# Altium schematic sheet NOTECARD.SchDoc
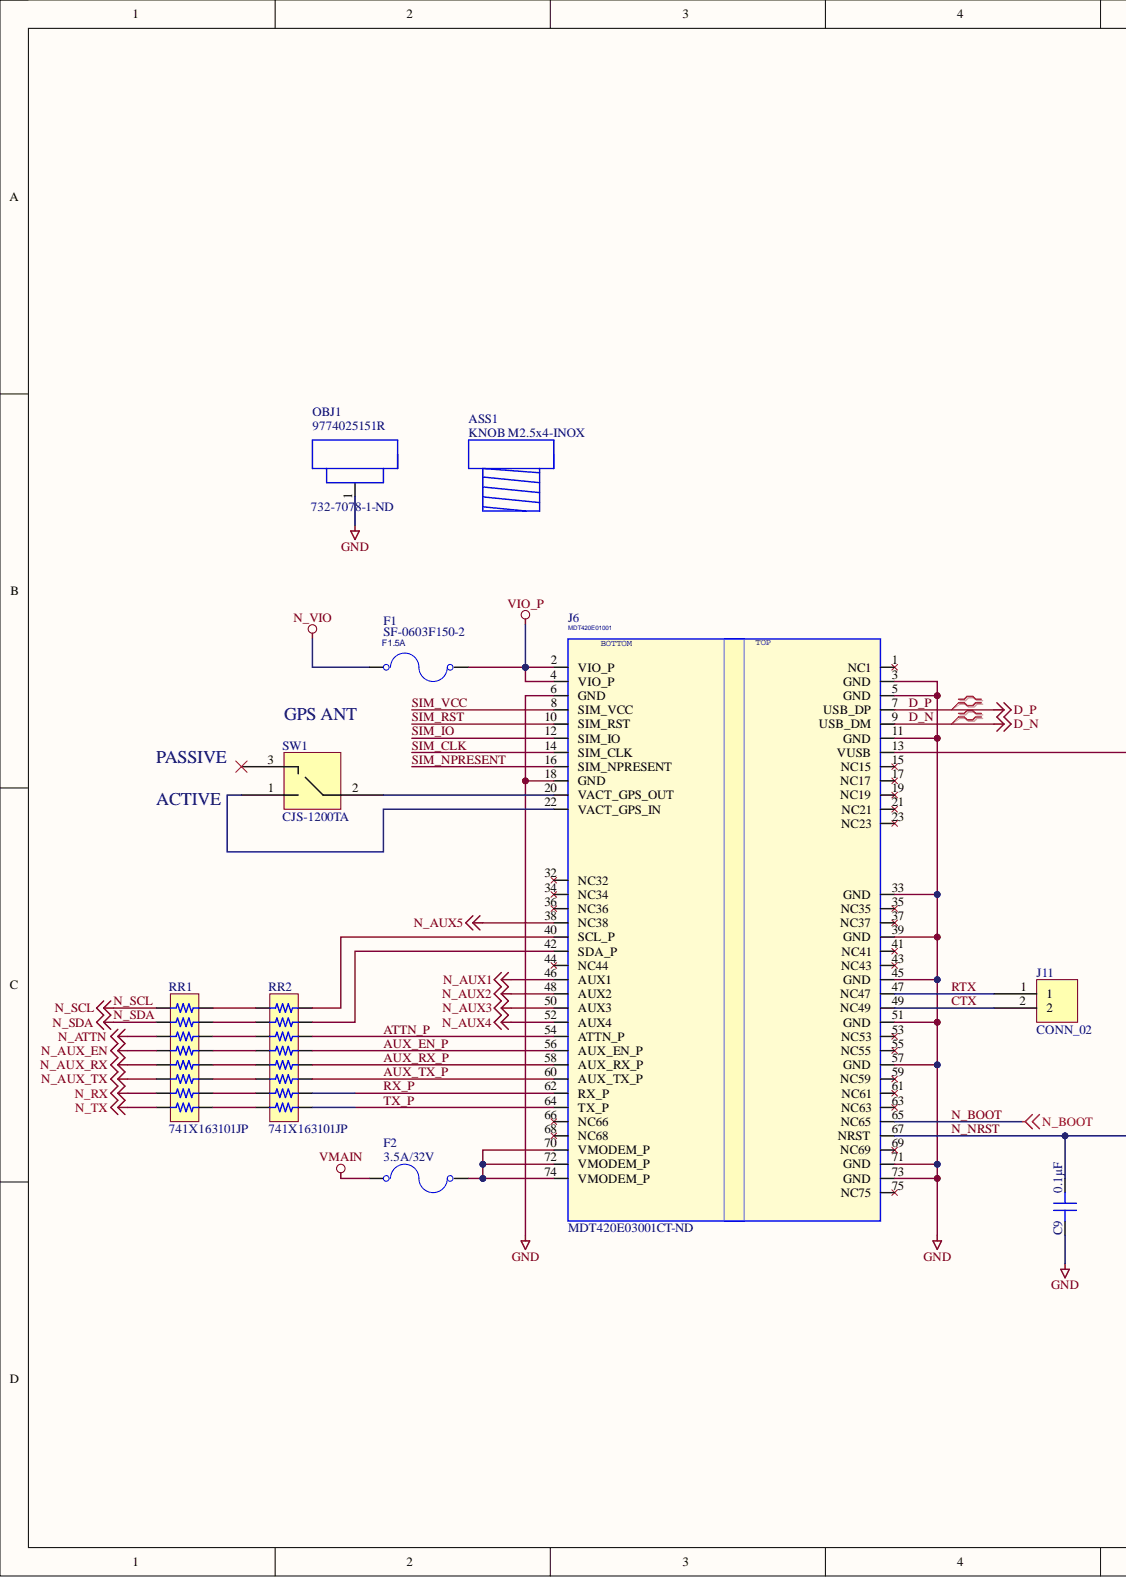
[diagram p0: NOTECARD.SchDoc, left]
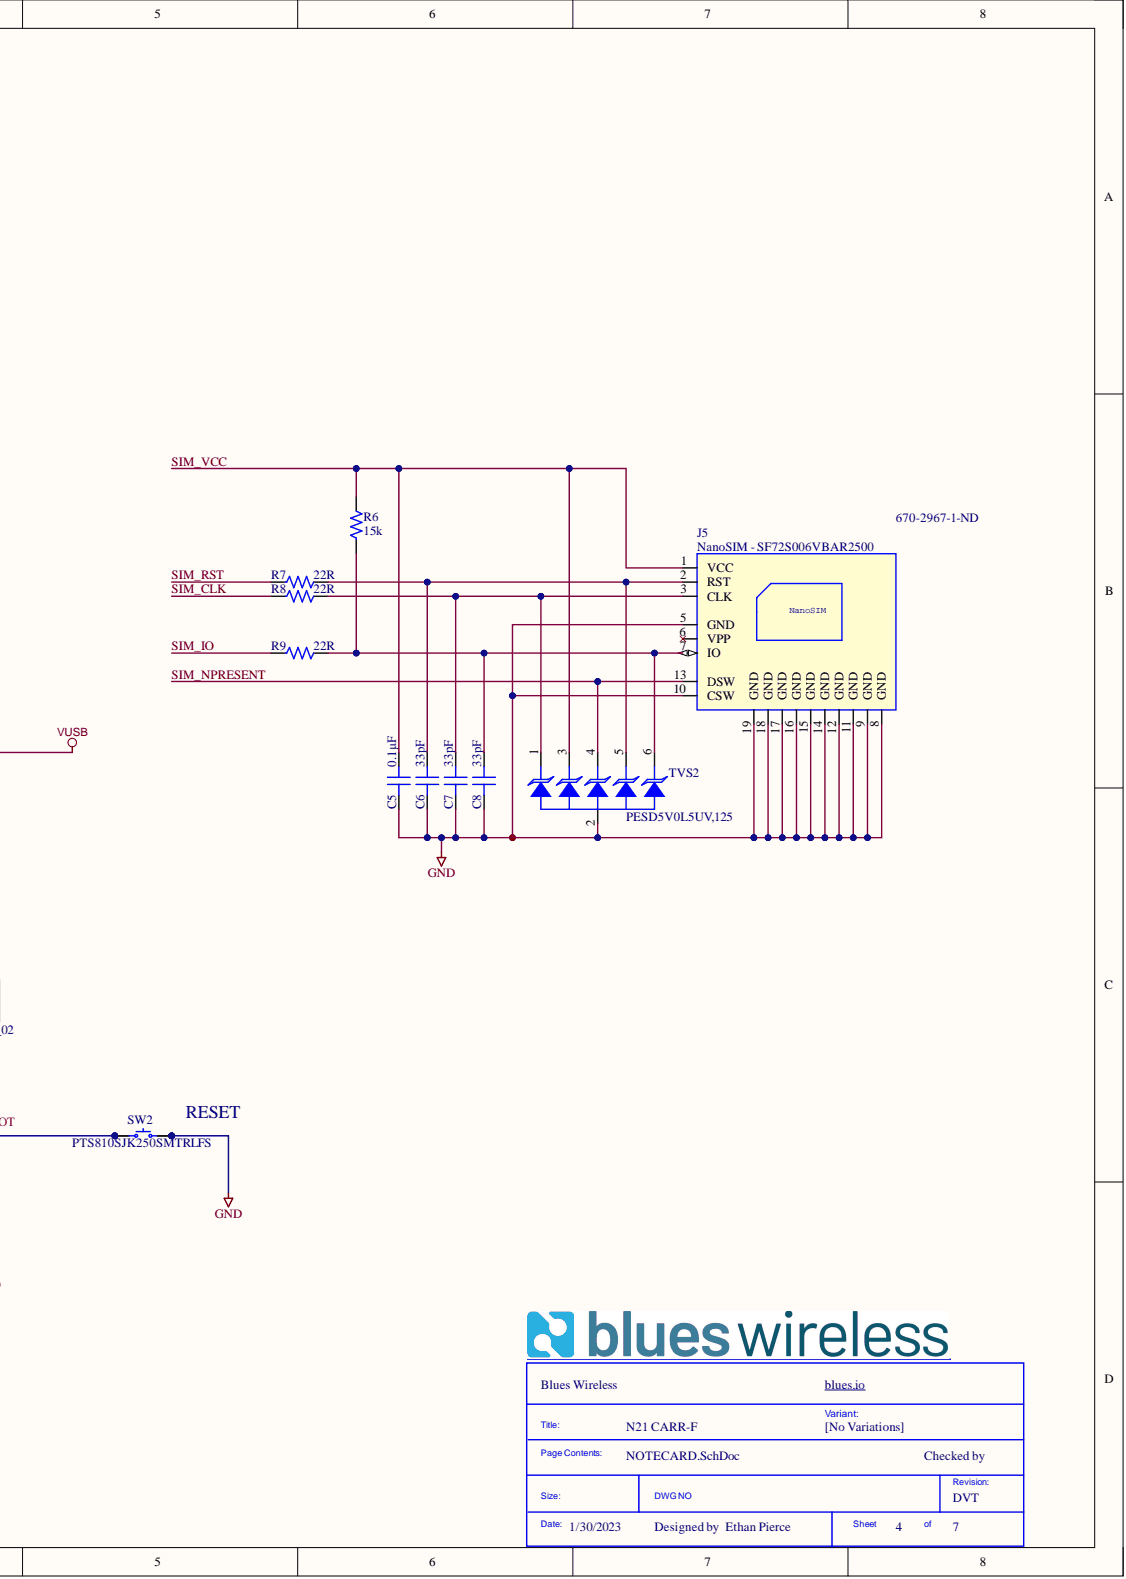
[diagram p1: NOTECARD.SchDoc, right]

## Parts
ASS1  KNOB M2.5x4-INOX  Modello: KNOB  (mechanical)
C5  0.1µF  [CAP 0402_1005]
C6  33pF  [CAP 0402_1005]
C7  33pF  [CAP 0402_1005]
C8  33pF  [CAP 0402_1005]
C9  0.1µF  [CAP 0402_1005]
F1  SF-0603F150-2  [FS-0603]
F2  3.5A/32V  [FS-0603]
J5  NanoSIM - SF72S006VBAR2500  Modello: NanoSIM  Supplier Part Number 1: 670-2967-1-ND  [J-NANOSIM-SF72S006VBA]
J6  MDT420E01001  Supplier Part Number 1: MDT420E03001CT-ND  [J-75-0050-MOS-M2]
J11  CONN_02  [CONN_02]  (value not drawn)  (no BOM)
OBJ1  9774025151R  Supplier Part Number 1: 732-7078-1-ND  [DIST-WASMSIM0250]
R6  15k  [RES 0402_1005]
R7  22R  [RES 0402_1005]
R8  22R  [RES 0402_1005]
R9  22R  [RES 0402_1005]
RR1  741X163101JP  [RESNET 1506-16 CONVEX]
RR2  741X163101JP  [RESNET 1506-16 CONVEX]
SW1  CJS-1200TA  [SW_CJS-1200TA]
SW2  PTS810SJK250SMTRLFS  [C&K PTS810]
TVS2  PESD5V0L5UV,125  [SOT666]

## Nets
GND: C5.1 C6.1 C7.1 C8.1 C9.1 J5.5(GND) J5.8(GND) J5.9(GND) J5.10(CSW) J5.11(GND) J5.12(GND) J5.14(GND) J5.15(GND) J5.16(GND) J5.17(GND) J5.18(GND) J5.19(GND) J6.3(GND) J6.5(GND) J6.6(GND) J6.11(GND) J6.18(GND) J6.33(GND) J6.39(GND) J6.45(GND) J6.51(GND) J6.57(GND) J6.71(GND) J6.73(GND) OBJ1.1 SW2.3 SW2.4 TVS2.2
N_ATTN: RR1.3
N_AUX1: J6.46(AUX1)
N_AUX2: J6.48(AUX2)
N_AUX3: J6.50(AUX3)
N_AUX4: J6.52(AUX4)
N_AUX5: J6.38(NC38)
N_AUX_EN: RR1.4
N_AUX_RX: RR1.5
N_AUX_TX: RR1.6
N_RX: RR1.7
N_TX: RR1.8
N_VIO: F1.1
VIO_P: F1.2 J6.2(VIO_P) J6.4(VIO_P)
VMAIN: F2.1
VUSB: J6.13(VUSB)
ATTN_P: J6.54(ATTN_P) RR2.14
AUX_EN_P: J6.56(AUX_EN_P) RR2.13
AUX_RX_P: J6.58(AUX_RX_P) RR2.12
AUX_TX_P: J6.60(AUX_TX_P) RR2.11
CTX: J6.49(NC49) J11.2
D_N: J6.9(USB_DM)
D_P: J6.7(USB_DP)
N_BOOT: J6.65(NC65)
N_NRST: C9.2 J6.67(NRST) SW2.1 SW2.2
N_SCL: RR1.1
N_SDA: RR1.2
RTX: J6.47(NC47) J11.1
RX_P: J6.62(RX_P) RR2.10
SIM_CLK: J6.14(SIM_CLK) R8.1
SIM_IO: J6.12(SIM_IO) R9.1
SIM_NPRESENT: J5.13(DSW) J6.16(SIM_NPRESENT) TVS2.4
SIM_RST: J6.10(SIM_RST) R7.1
SIM_VCC: C5.2 J5.1(VCC) J6.8(SIM_VCC) R6.2 TVS2.3
TX_P: J6.64(TX_P) RR2.9
NetC6_2: C6.2 J5.2(RST) R7.2 TVS2.5
NetC7_2: C7.2 J5.3(CLK) R8.2 TVS2.1
NetC8_2: C8.2 J5.7(IO) R6.1 R9.2 TVS2.6
NetF2_2: F2.2 J6.70(VMODEM_P) J6.72(VMODEM_P) J6.74(VMODEM_P)
NetJ6_20: J6.20(VACT_GPS_OUT) SW1.2
NetJ6_22: J6.22(VACT_GPS_IN) SW1.1
NetJ6_40: J6.40(SCL_P) RR2.16
NetJ6_42: J6.42(SDA_P) RR2.15
NetRR1_9: RR1.9 RR2.8
NetRR1_10: RR1.10 RR2.7
NetRR1_11: RR1.11 RR2.6
NetRR1_12: RR1.12 RR2.5
NetRR1_13: RR1.13 RR2.4
NetRR1_14: RR1.14 RR2.3
NetRR1_15: RR1.15 RR2.2
NetRR1_16: RR1.16 RR2.1

Not connected: J5 6(VPP); J6 1(NC1) 15(NC15) 17(NC17) 19(NC19) 21(NC21) 23(NC23) 32(NC32) 34(NC34) 35(NC35) 36(NC36) 37(NC37) 41(NC41) 43(NC43) 44(NC44) 53(NC53) 55(NC55) 59(NC59) 61(NC61) 63(NC63) 66(NC66) 68(NC68) 69(NC69) 75(NC75); SW1 3

## Library data of the parts
ASS1 = KNOB - M2.5x4-INOX: VITE TCEI 2.5X4 ESAGONO INCASSATO A2 INOX; Caratteristiche: M2.5; Famiglia: MECCANICA; Pkg Type: THT; Library Name: n21-p2.SchLib; Price Distributor Cad: 0,06
C5 C9 = CL05B104KO5NNNC: CAP CER 0.1UF 16V X7R 0402; Manufacturer: Samsung Electro-Mechanics; Database Table Name: Capacitors - Ceramic - 0402; Tolerance: ±10%; Applications: General Purpose; Capacitance: 0.1µF; Component Kind: Standard; ComponentLink1Description: Datasheet; ComponentLink1URL: http://www.samsungsem.com/kr/support/product-search/mlcc/CL05B104KO5NNNC.jsp; ComponentLink2Description: DigiKey Link; ComponentLink2URL: http://digikey.com/product-detail/en/samsung-electro-mechanics/CL05B104KO5NNNC/1276-1001-1-ND/3889087; Device Package: 0402 (1005 Metric); LastUpdated: 9/2/2020 1:02:15 AM; Library Name: Celestial Altium Library - altium_library.DbLib; Lifecycle Status: Active; Minimum Order: 1; Mounting Type: Surface Mount, MLCC; Operating Temperature: -55°C ~ 125°C; Package / Case: 0402 (1005 Metric); Packaging: CutTape; Part Number: CL05B104KO5NNNC; Part Status: Active; PartId: 6066; Price: 0.10; Series: CL; Size / Dimension: 0.039" L x 0.020" W (1.00mm x 0.50mm); Supplier 1: DigiKey; Supplier Part Number 1: 1276-1001-1-ND; Temperature Coefficient: X7R; Thickness (Max): 0.022" (0.55mm); Value: 0.1µF; Voltage - Rated: 16V
C6-C8 = CL05C330JB5NNNC: CAP CER 33PF 50V C0G/NP0 0402; Manufacturer: Samsung Electro-Mechanics; Database Table Name: Capacitors - Ceramic - 0402; Temperature Coefficient: C0G, NP0; Tolerance: ±5%; Applications: General Purpose; Capacitance: 33pF; Component Kind: Standard; ComponentLink1Description: Datasheet; ComponentLink1URL: http://www.samsungsem.com/kr/support/product-search/mlcc/CL05C330JB5NNNC.jsp; ComponentLink2Description: DigiKey Link; ComponentLink2URL: http://digikey.com/product-detail/en/samsung-electro-mechanics/CL05C330JB5NNNC/1276-1272-1-ND/3889358; Device Package: 0402 (1005 Metric); LastUpdated: 9/2/2020 1:02:02 AM; Library Name: Celestial Altium Library - altium_library.DbLib; Lifecycle Status: Active; Minimum Order: 1; Mounting Type: Surface Mount, MLCC; Operating Temperature: -55°C ~ 125°C; Package / Case: 0402 (1005 Metric); Packaging: CutTape; Part Number: CL05C330JB5NNNC; Part Status: Active; PartId: 11655; Price: 0.10; Series: CL; Size / Dimension: 0.039" L x 0.020" W (1.00mm x 0.50mm); Supplier 1: DigiKey; Supplier Part Number 1: 1276-1272-1-ND; Thickness (Max): 0.022" (0.55mm); Value: 33pF; Voltage - Rated: 50V
F1 = RAPIDO - SF-0603F150-2-1.5A/32V: FUSIBILE RAPIDO 1.5A 32V 0603 SF-0603F150-2 BOURNS SMT; Database: 32505; Pkg Type: SMD; Temp Range: -40°..+105°; Caratteristiche: 1.5A/32V; Component Kind: Standard; Distributor: FAE; Famiglia: FUSIBILE; Modello: RAPIDO; MPN: SF-0603F150-2; PCB Footprint: FS-0603; Price Distributor Cad: 0,1669; Library Name: notecarrier-afv2-V1I1.SchLib
F2 = RAPIDO - 0603SFV350F- 3.5A/32V: FUSIBILE RAPIDO 3.5A 32V 0603 0603SFV350F/32-2 LITTELFUSE SMT; Database: 40054; Pkg Type: SMD; Temp Range: -55°..+125°; Caratteristiche: 3.5A/32V; Component Kind: Standard; Distributor: FAE; Famiglia: FUSIBILE; Modello: RAPIDO; MPN: 0603SFV350F/32-2; PCB Footprint: FS-0603; Price Distributor Cad: 0,4034; Library Name: notecarrier-afv2-V1I1.SchLib
J5 = NANOSIM - J-NANOSIM-SF72S006VBA: CONN. NANOSIM 8P F/90° PUSH-PUSH SF72S006VBAR2500 JAE ELECTRONICS SMT; Database: 41049; Famiglia: CONNETTORE; Pkg Type: SMD; ROOM: NANO-SIM; Temp Range: -25°..+85°; Component Kind: Standard; Distributor: FAE; PCB Footprint: J-NANOSIM-SF72S006VBA; Price Distributor Cad: 0,7362; Supplier 1: Digi-Key; Library Name: notecarrier-afv2-V1I1.SchLib
J6 = AMPHENOL-75 - M-2 KEY E_13: CONN. M.2 75P M/90° P=0.5 MDT420E01001 AMPHENOL SMT; Famiglia: CONNETTORE; PCB Footprint: J-75-0050-MOS-M2-E; Pkg Type: SMD; ROOM: M2 CONNECTOR; Temp Range: -40°..+80°; Caratteristiche: 75P; Component Kind: Standard; Distributor: D-MDT420E01001CT-ND; Price Distributor Cad: 1,21; Supplier 1: Digi-Key; Library Name: notecarrier-afv2-V1I1.SchLib
J11 = CONN_02: 0,1" 2 PIN Header; Library Name: n21-p2.SchLib
OBJ1 = DISTANZIALE - M2.5x0250: DISTANZ. CIL. METALLO 5.1X2.5 9774025151R W.E SMT; Caratteristiche: M2.5; Database: 44635; Famiglia: MECCANICA; Pkg Type: SMD; Component Kind: Standard; Distributor: FAE; Modello: DISTANZIALE; PCB Footprint: DIST-WASMSIM0250; Price Distributor Cad: 0,6225; Supplier 1: Digi-Key; Library Name: notecarrier-afv2-V1I1.SchLib
R6 = PFR05S-153-FNH: Res SMD 15 Kohm 1% 1/16W 0402; Manufacturer: Cyntec; Category: Chip SMD Resistors; Component Kind: Standard; Manufacturer Part Number: PFR05S-153-FNH; Pricing 1: 1=0.10000, 10=0.01500, 100=0.00590, 1000=0.00265, 2500=0.00230, 5000=0.00190 (USD); RoHS: Yes; RoHS Compliant: Yes; Stock 1: 3928; Supplier 1: Digi-Key; Supplier Part Number 1: 2037-PFR05S-153-FNHCT-ND; Library Name: notecarrier-afv2-V1I1.SchLib
R7-R9 = RC0402FR-0722RL: Res Thick Film 0402 22 Ohm 1% 0.063W(1/16W) ±100ppm/C Pad SMD T/R; Manufacturer: Yageo; Max Power Dissipation: 63mW; Temperature Coefficient: 100ppm/°C; Voltage Rating: 50V; Case Code (Imperial): 0402; Case Code (Metric): 1005; Case/Package: 0402; Category: Chip SMD Resistors; Component Kind: Standard; ComponentLink1Description: https://datasheet.ciiva.com/11819/0900766b80c66297-11819462.pdf?src-supplier=RSComponents; ComponentLink1URL: https://datasheet.ciiva.com/11819/0900766b80c66297-11819462.pdf?src-supplier=RSComponents; ComponentLink2Description: https://datasheet.ciiva.com/16930/pyu-rc0402-51-rohs-l-6-r-16930687.pdf?src-supplier=Future+Electronics; ComponentLink2URL: https://datasheet.ciiva.com/16930/pyu-rc0402-51-rohs-l-6-r-16930687.pdf?src-supplier=Future+Electronics; ComponentLink3Description: https://datasheet.ciiva.com/7849/1018594-7849911.pdf?src-supplier=Element14; ComponentLink3URL: https://datasheet.ciiva.com/7849/1018594-7849911.pdf?src-supplier=Element14; ComponentLink4Description: https://datasheet.ciiva.com/7849/1018594-7849912.pdf?src-supplier=Element14; ComponentLink4URL: https://datasheet.ciiva.com/7849/1018594-7849912.pdf?src-supplier=Element14; ComponentLink5Description: https://datasheet.ciiva.com/26829/getdatasheetpartid-554995-26829991.pdf?src-supplier=Verical; ComponentLink5URL: https://datasheet.ciiva.com/26829/getdatasheetpartid-554995-26829991.pdf?src-supplier=Verical; Construction: Rectangular; Depth: 0.5mm; Features: Moisture Resistant; Height: 0.32mm; Lead Free: Lead Free; Length: 1mm; Manufacturer Part Number: RC0402FR-0722RL; Max Operating Temperature: 155°C; Military Standard: Not; Min Operating Temperature: -55°C; Number of Pins: 2; Number of Terminations: 2; Packaging: Tape and Reel; Power Rating: 63mW; Pricing 1: 1=0.10000, 10=0.01600, 100=0.00660, 1000=0.00299, 2500=0.00259, 5000=0.00214 (USD); REACH SVHC: Unknown; Resistance: 22R; Resistance Tolerance: 1%; Resistor Type: Thick Film; RoHS: Yes; RoHS Compliant: Yes; Series: RC; Size Code: 0402; Stock 1: 1796; Supplier 1: Digi-Key; Supplier Part Number 1: 311-22.0LRCT-ND; Technology: THICK FILM; Termination: SMD/SMT; Tolerance: 1%; Voltage Rating (DC): 50V; Width: 0.5mm; Library Name: notecarrier-afv2-V1I1.SchLib
RR1 RR2 = 741X163101JP: RES ARRAY 8 RES 100 OHM 1506; Manufacturer: CTS Resistor Products; Circuit Type: Isolated; Database Table Name: Resistors -  Arrays and Networks; Number of Resistors: 8; Power Per Element: 62.5mW; Resistance (Ohms): 100; Temperature Coefficient: ±200ppm/°C; Tolerance: ±5%; Component Kind: Standard; ComponentLink1Description: Datasheet; ComponentLink1URL: https://www.ctscorp.com/wp-content/uploads/74x.pdf; ComponentLink2Description: DigiKey Link; ComponentLink2URL: http://digikey.com/product-detail/en/cts-resistor-products/741X163101JP/741X163101JPCT-ND/1124597; Height - Seated (Max): 0.022" (0.55mm); LastUpdated: 9/2/2020 1:23:29 AM; Library Name: Celestial Altium Library - altium_library.DbLib; Lifecycle Status: Active; Minimum Order: 1; Mounting Type: Surface Mount; Number of Pins: 16; Operating Temperature: -55°C ~ 125°C; Package / Case: 1506, Convex, Long Side Terminals; Packaging: TapeAndReel; Part Number: 741X163101JP; Part Status: Active; PartId: 627; Price: 0.07; Series: 741; Size / Dimension: 0.156" L x 0.063" W (3.95mm x 1.60mm); Supplier 1: DigiKey; Supplier Part Number 1: 741X163101JPCT-ND
SW1 = CJS-1200TA: Switch Slide N.O./N.C. SPDT Raised Slide 0.1A 24VDC J-Hook SMD Embossed T/R; Manufacturer: Nidec Copal; Actuator Type: Slide (Standard); Body Material: Polyphenylene; Current Rating: 100mA; Electrical Life: 200Cycles; Max Voltage Rating (DC): 24V; Number of Positions: 2; Voltage Rating (DC): 6V; Washable: Yes; Category: Slide Switches; Component Kind: Standard; ComponentLink1Description: https://datasheet.ciiva.com/2864/cjs-2864476.pdf?src-supplier=Digi-Key; ComponentLink1URL: https://datasheet.ciiva.com/2864/cjs-2864476.pdf?src-supplier=Digi-Key; ComponentLink2Description: https://datasheet.ciiva.com/2864/cjs-2864477.pdf?src-supplier=Digi-Key; ComponentLink2URL: https://datasheet.ciiva.com/2864/cjs-2864477.pdf?src-supplier=Digi-Key; ComponentLink3Description: https://datasheet.ciiva.com/2864/cjs-2864478.pdf?src-supplier=Digi-Key; ComponentLink3URL: https://datasheet.ciiva.com/2864/cjs-2864478.pdf?src-supplier=Digi-Key; Contact Material: Copper Alloy; Contact Plating: Gold; Lead Free: Lead Free; Length: 0.212inch; Manufacturer Part Number: CJS-1200TA; Max Operating Temperature: 85°C; Min Operating Temperature: -40°C; Mount: Surface Mount; Orientation: Vertical; Packaging: Tape and Reel; Pricing 1: 1=0.81000, 10=0.78400, 25=0.75440, 100=0.65470, 250=0.59736, 500=0.55510 (USD); Radiation Hardening: No; RoHS: Yes; RoHS Compliant: Yes; Series: CJS; Supplier 1: Digi-Key; Supplier Part Number 1: 563-1021-6-ND; Termination: J-Hook; Throw Configuration: SPDT; Library Name: notecarrier-afv2-V1I1.SchLib
SW2 = PTS810SJK250SMTRLFS: PTS810 Series SPST Off-MOM 0.05 A 16 V Top Actuated SMT Tactile Switch; Manufacturer: ITT C&K; Actuator Type: Standard; Current Rating: 50mA; Illumination Type: Non-Illuminated; Mechanical Life: 50000Cycles; Operating Force: 260gf; Switch Travel: 0.15mm; Voltage Rating (DC): 16V; Case/Package: SMD/SMT; Category: Tactile Switches; Color: White; Component Kind: Standard; ComponentLink1Description: https://datasheet.ciiva.com/18514/pts810-4mar13-18514061.pdf?src-supplier=Digi-Key; ComponentLink1URL: https://datasheet.ciiva.com/18514/pts810-4mar13-18514061.pdf?src-supplier=Digi-Key; ComponentLink2Description: https://datasheet.ciiva.com/18514/pts810-4mar13-18514062.pdf?src-supplier=Digi-Key; ComponentLink2URL: https://datasheet.ciiva.com/18514/pts810-4mar13-18514062.pdf?src-supplier=Digi-Key; ComponentLink3Description: https://datasheet.ciiva.com/18514/pts810-4mar13-18514063.pdf?src-supplier=Digi-Key; ComponentLink3URL: https://datasheet.ciiva.com/18514/pts810-4mar13-18514063.pdf?src-supplier=Digi-Key; Contact Plating: Silver; Depth: 4.6mm; Lead Free: Lead Free; Length: 3.2mm; Manufacturer Part Number: PTS810SJK250SMTRLFS; Max Current Rating: 50mA; Max Operating Temperature: 85°C; Min Operating Temperature: -40°C; Mount: Surface Mount; Orientation: Straight; Package Quantity: 2900; Packaging: Tape and Reel; Pricing 1: 1=0.34000, 10=0.32600, 25=0.30880, 100=0.27980, 250=0.25504, 500=0.23706, 1000=0.20223 (USD); RoHS: Yes; RoHS Compliant: Yes; Stock 1: 57; Supplier 1: Digi-Key; Supplier Part Number 1: CKN10503CT-ND; Switch Function: Off-Mom; Termination: J-Bend; Throw Configuration: SPST; Library Name: notecarrier-afv2-V1I1.SchLib
TVS2 = PESD5V0L5UV,125: PESD Series 25 W 5 V Uni-Directional Fivefold ESD Protection Diode Array SOT-666; Manufacturer: Nexperia; Category: ESD and Circuit Protection ICs; Component Kind: Standard; Manufacturer Part Number: PESD5V0L5UV,125; Pricing 1: 1=0.42000, 10=0.31700, 100=0.19770, 500=0.13528, 1000=0.10406, 2000=0.09366 (USD); RoHS: Yes; RoHS Compliant: Yes; Stock 1: 4000; Supplier 1: Digi-Key; Supplier Part Number 1: 1727-5797-1-ND; Library Name: notecarrier-afv2-V1I1.SchLib
